# Altium schematic sheet 12_RS485_R232.SchDoc(12_RS485_R232)
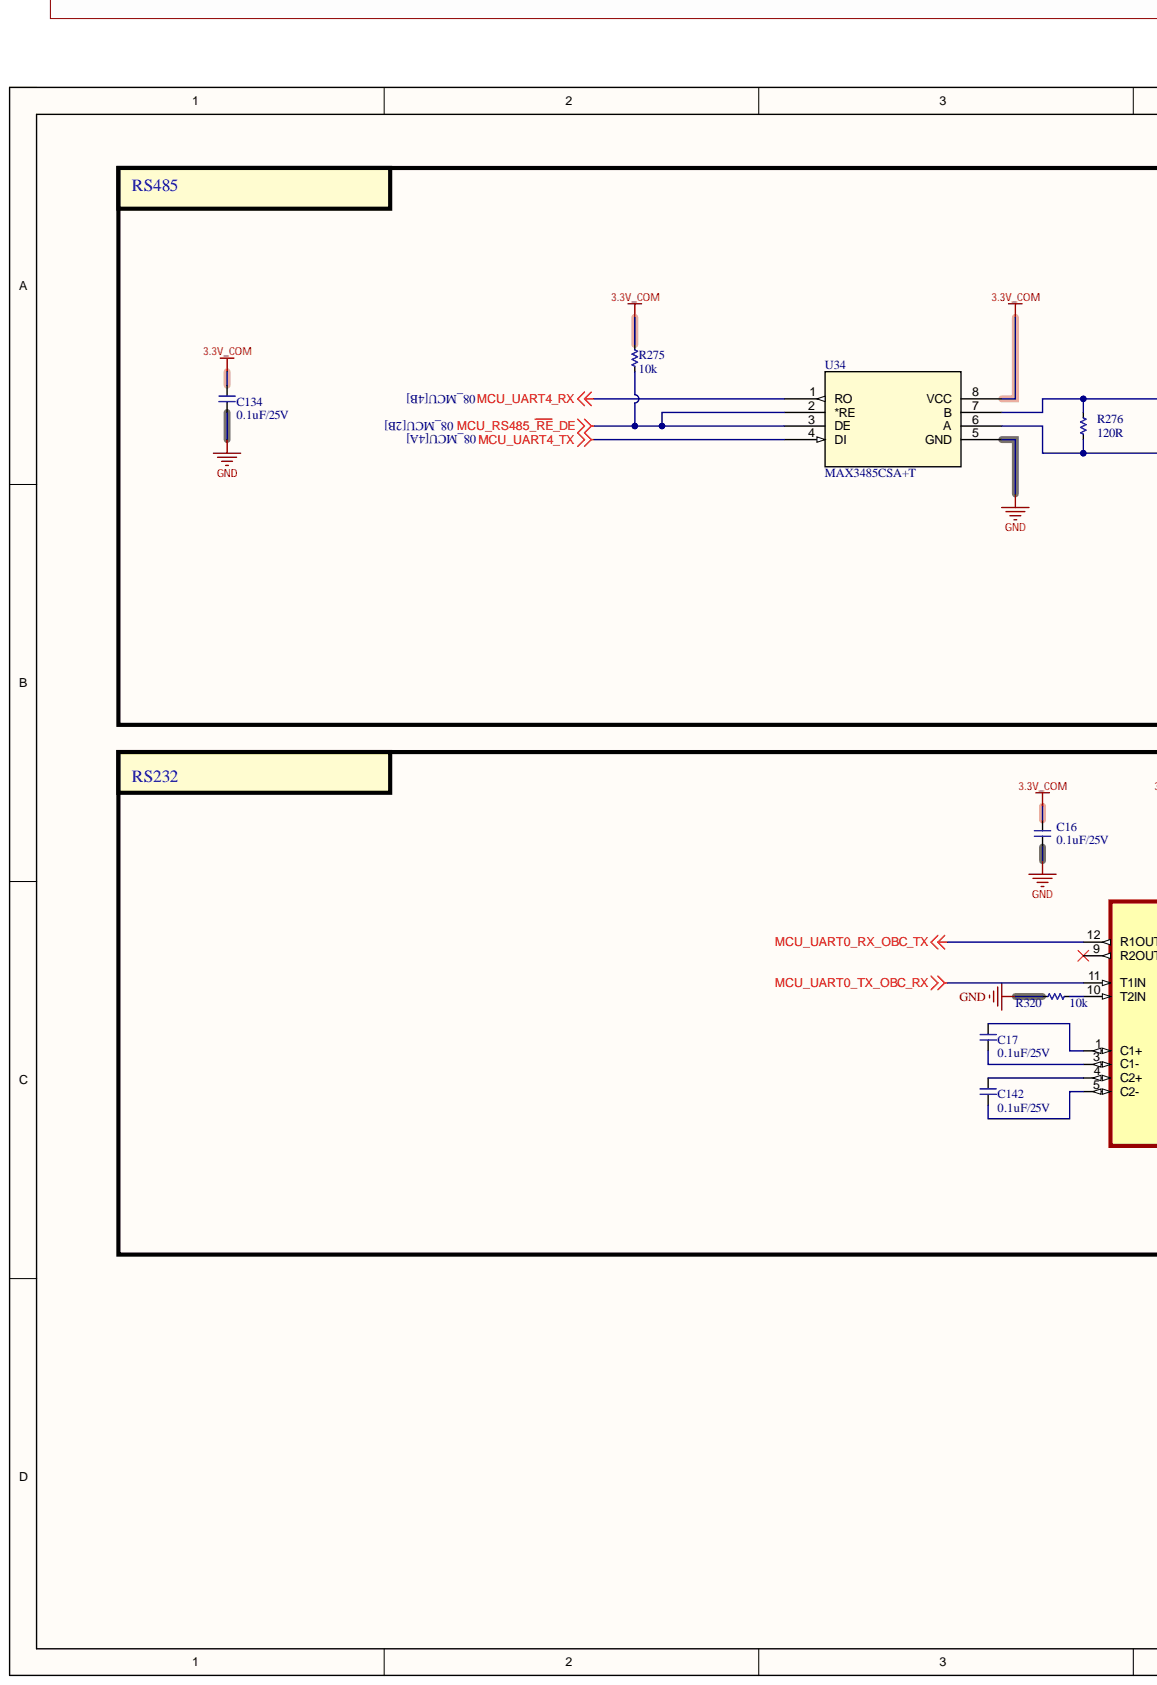
[diagram p0: 12_RS485_R232.SchDoc, left]
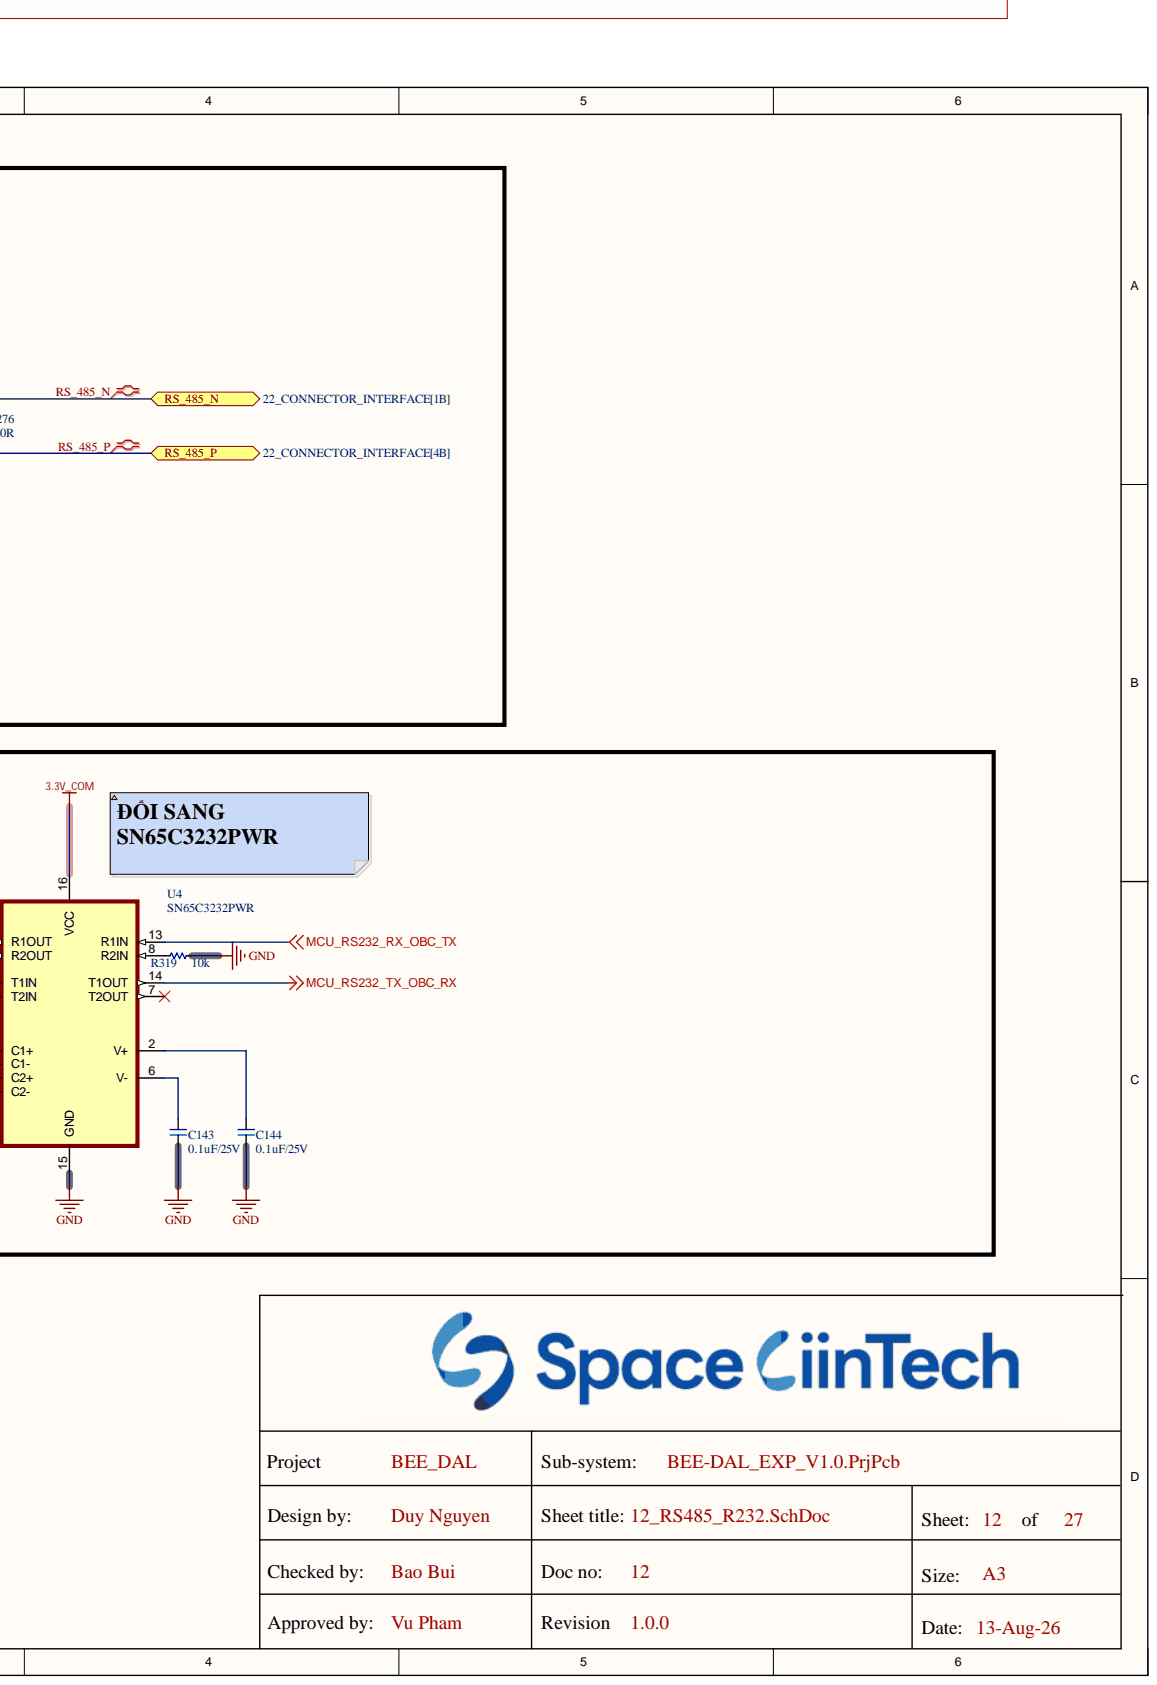
[diagram p1: 12_RS485_R232.SchDoc, right]

## Parts
C16  0.1uF/25V  [CAP_C_1608X80]
C17  0.1uF/25V  [CAP_C_1005X60]
C134  0.1uF/25V  [CAP_C_1005X60]
C142  0.1uF/25V  [CAP_C_1005X60]
C143  0.1uF/25V  [CAP_C_1005X60]
C144  0.1uF/25V  [CAP_C_1005X60]
R275  10k  [RES_C_1005X40]
R276  120R  [RES_C_1005X40]
R319  10k  [RES_C_1005X40]
R320  10k  [RES_C_1005X40]
U4  SN65C3232PWR  [SOP65P640X120-16N]
U34  MAX3485CSA+T  [21-0041-3-M_90-0096-8L_MXM]

## Ports
RS_485_N, RS_485_P

## Nets
3.3V_COM: C16.2 C134.2 R275.1 U4.16(VCC) U34.8(VCC)
GND: C16.1 C134.1 C143.1 C144.1 R319.1 R320.1 U4.15(GND) U34.5(GND)
MCU_RS232_RX_OBC_TX: U4.13(R1IN)
MCU_RS232_TX_OBC_RX: U4.14(T1OUT)
MCU_RS485_~{RE}_DE: R275.2 U34.2(*RE) U34.3(DE)
MCU_UART0_RX_OBC_TX: U4.12(R1OUT)
MCU_UART0_TX_OBC_RX: U4.11(T1IN)
MCU_UART4_RX: U34.1(RO)
MCU_UART4_TX: U34.4(DI)
RS_485_N: R276.1 U34.7(B) ; port RS_485_N
RS_485_P: R276.2 U34.6(A) ; port RS_485_P
NetC17_1: C17.1 U4.3(C1-)
NetC17_2: C17.2 U4.1(C1+)
NetC142_1: C142.1 U4.5(C2-)
NetC142_2: C142.2 U4.4(C2+)
NetC143_2: C143.2 U4.6(V-)
NetC144_2: C144.2 U4.2(V+)
NetR319_2: R319.2 U4.8(R2IN)
NetR320_2: R320.2 U4.10(T2IN)

Not connected: U4 7(T2OUT) 9(R2OUT)

## Library data of the parts
C16 C17 C134 C142-C144 = _CAP_C_2: CAP; Component Kind: Standard; Library Name: LABLIB.SchLib
R275 R276 R319 R320 = _RES_B_2: RES; Component Kind: Standard; Library Name: LABLIB.SchLib
U4 = IC_RS232_SN65C3232PWR: 2/2 Transceiver Full RS232 16-TSSOP 1GHz; MF: Analog Devices; MP: MAX3232CSE+T; Check_prices: https://www.snapeda.com/parts/MAX3232CSE+T/Analog+Devices/view-part/?ref=eda; Component Kind: Standard; Package: SOIC-16 Maxim Integrated; SnapEDA_Link: https://www.snapeda.com/parts/MAX3232CSE+T/Analog+Devices/view-part/?ref=snap; Library Name: LABLIB.SchLib
U34 = MAX3485CSA+T: Low-Power, Slew-Rate-Limited RS-485/RS-422 Transceivers, IC TRANSCEIVER HALF 1/1 8SOIC; Manufacturer_Name: Analog Devices Inc; Manufacturer_Part_Number: MAX485CSA+T; Component Kind: Standard; RefDes: RefDes; Type: Type; Copyright: Copyright (C) 2025 Ultra Librarian. All rights reserved.; Library Name: BEE-DAL_EXP_sche.SCHLIB
